# Altium schematic sheet DRIVER.SchDoc(DRIVER)
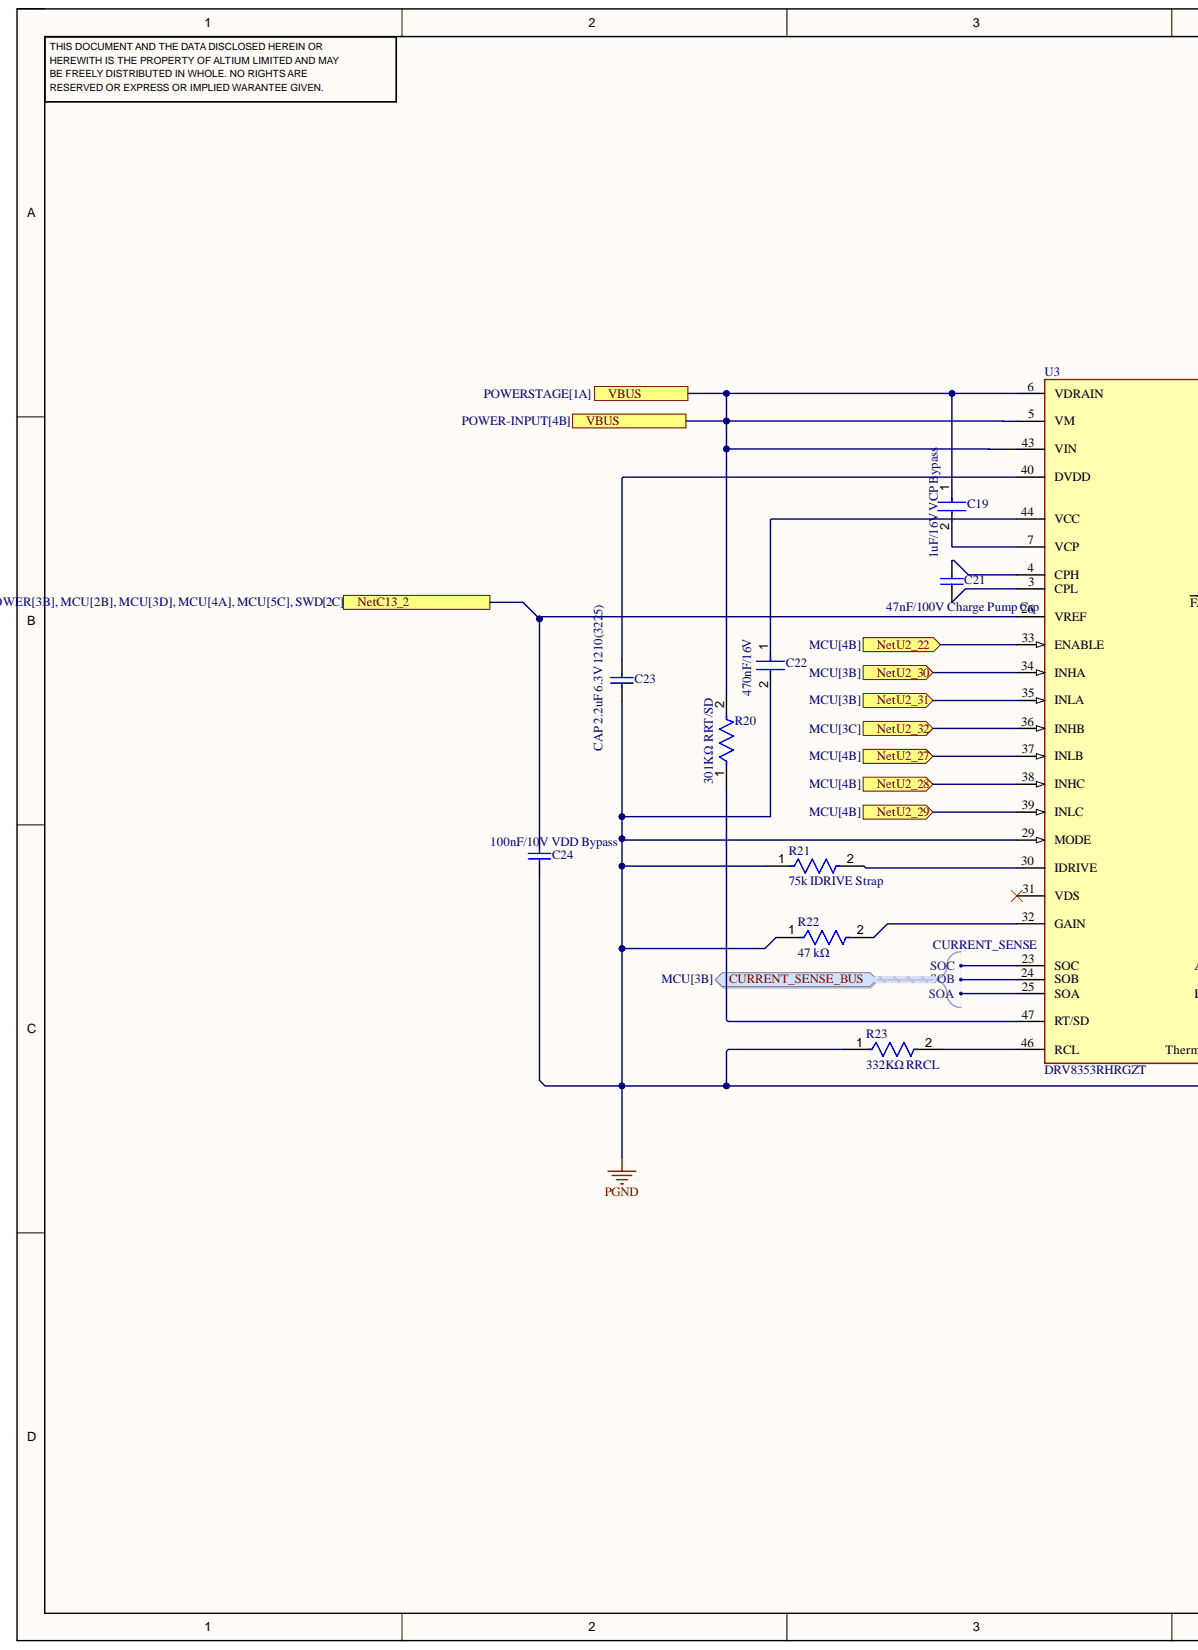
[diagram p0: DRIVER.SchDoc, left]
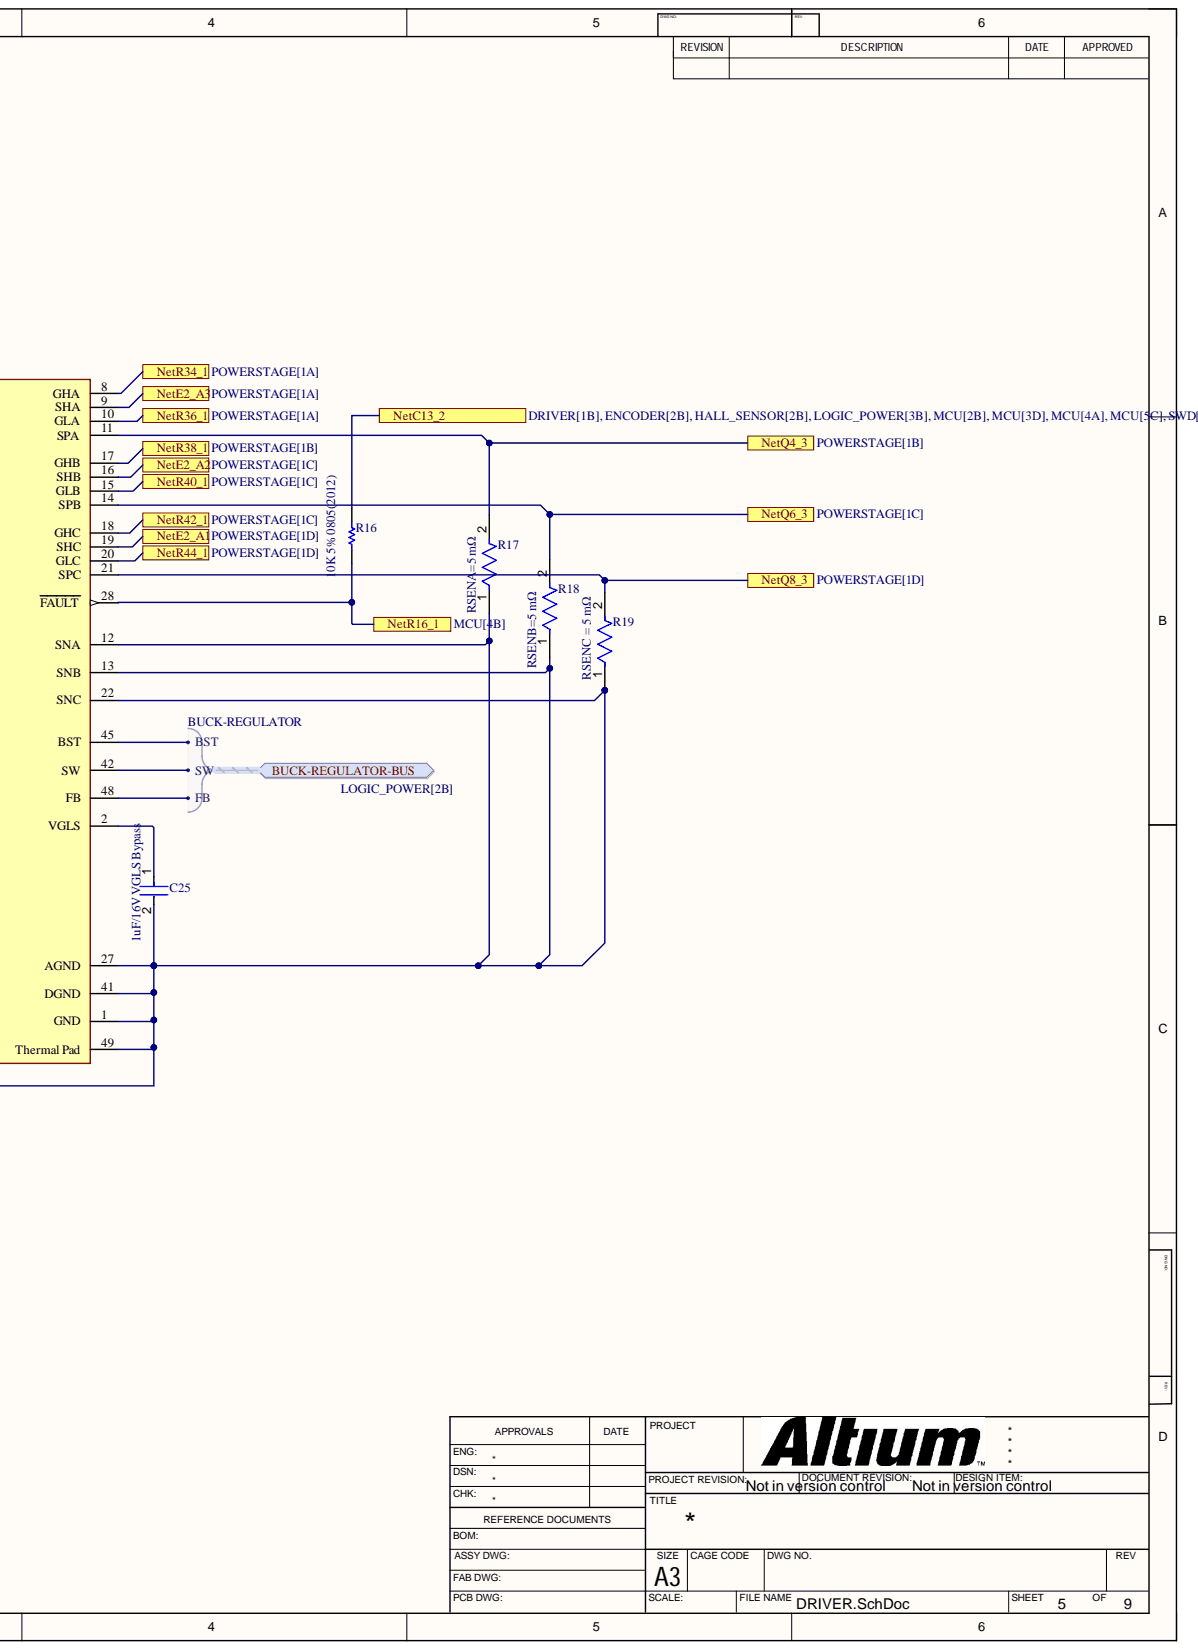
[diagram p1: DRIVER.SchDoc, right]

## Parts
C19  1uF/16V VCP Bypass  [FP-0603-L_1_6_0_1-W_0_8_0-IPC_A]
C21  47nF/100V Charge Pump Cap  [CAPC1206(3216)170_L]
C22  470nF/16V  [FP-0201-L_0_6_0_09-W_0_3-MFG]
C23  CAP 2.2uF 6.3V 1210(3225)  [CAPC2013X145X50ML20T20]
C24  100nF/10V VDD Bypass  [CAPC0805(2012)100_N]
C25  1uF/16V VGLS Bypass  [FP-0603-L_1_6_0_1-W_0_8_0-IPC_A]
R16  10K 5% 0805(2012)  [RESC0805(2012)_L]
R17  RSENA=5 mΩ  [FP-CRE2512-R005-R009-MFG]
R18  RSENB=5 mΩ  [FP-CRE2512-R005-R009-MFG]
R19  RSENC = 5 mΩ  [FP-CRE2512-R005-R009-MFG]
R20  301KΩ  RRT/SD  value 301KΩ  Resistance: 301KΩ  [FP-RP73P-1J-MFG]
R21  75k IDRIVE Strap  [FP-CRCW0603-e3-IPC_C]
R22  47 kΩ  [FP-CRCW0805-HPe3-IPC_B]
R23  332KΩ RRCL  value 332KΩ  Resistance: 332KΩ  [FP-RN732A-MFG]
U3  DRV8353RHRGZT  [FP-RGZ0048L-MFG]

## Ports
3.3V-LOGIC-SUPPLY, BUCK-REGULATOR-BUS, BUCK-REGULATOR-BUS.BST, BUCK-REGULATOR-BUS.FB, BUCK-REGULATOR-BUS.SW, CURRENT_SENSE_BUS, CURRENT_SENSE_BUS.SOA, CURRENT_SENSE_BUS.SOB, CURRENT_SENSE_BUS.SOC, ENABLE, FAULT, GHA, GHB, GHC, GLA, GLB, GLC, INHA, INHB, INHC, INLA, INLB, INLC, SHA, SHB, SHC, SPA, SPB, SPC, VBUS_MOTOR, VDRAIN

## Nets
GND: C22.2 C23.1 C24.1 C25.2 R17.1 R18.1 R19.1 R21.1 R22.1 R23.1 U3.1(GND) U3.12(SNA) U3.13(SNB) U3.22(SNC) U3.27(AGND) U3.29(MODE) U3.41(DGND) U3.49(Thermal_Pad)
3.3V-LOGIC-SUPPLY: C24.2 R16.2 U3.26(VREF) ; port 3.3V-LOGIC-SUPPLY
ENABLE: U3.33(ENABLE) ; port ENABLE
GHA: U3.8(GHA) ; port GHA
GHB: U3.17(GHB) ; port GHB
GHC: U3.18(GHC) ; port GHC
GLA: U3.10(GLA) ; port GLA
GLB: U3.15(GLB) ; port GLB
GLC: U3.20(GLC) ; port GLC
INHA: U3.34(INHA) ; port INHA
INHB: U3.36(INHB) ; port INHB
INHC: U3.38(INHC) ; port INHC
INLA: U3.35(INLA) ; port INLA
INLB: U3.37(INLB) ; port INLB
INLC: U3.39(INLC) ; port INLC
SHA: U3.9(SHA) ; port SHA
SHB: U3.16(SHB) ; port SHB
SHC: U3.19(SHC) ; port SHC
SPA: R17.2 U3.11(SPA) ; port SPA
SPB: R18.2 U3.14(SPB) ; port SPB
SPC: R19.2 U3.21(SPC) ; port SPC
VBUS_MOTOR: C19.1 R20.2 U3.5(VM) U3.6(VDRAIN) U3.43(VIN) ; port VBUS_MOTOR, port VDRAIN
NetC19_2: C19.2 U3.7(VCP)
NetC21_1: C21.1 U3.3(CPL)
NetC21_2: C21.2 U3.4(CPH)
NetC22_1: C22.1 U3.44(VCC)
NetC23_2: C23.2 U3.40(DVDD)
NetC25_1: C25.1 U3.2(VGLS)
NetR16_1: R16.1 U3.28(FAULT) ; port FAULT
NetR20_1: R20.1 U3.47(RT/SD)
NetR21_2: R21.2 U3.30(IDRIVE)
NetR22_2: R22.2 U3.32(GAIN)
NetR23_2: R23.2 U3.46(RCL)
NetU3_23: U3.23(SOC) ; port CURRENT_SENSE_BUS
NetU3_24: U3.24(SOB) ; port CURRENT_SENSE_BUS
NetU3_25: U3.25(SOA) ; port CURRENT_SENSE_BUS
NetU3_42: U3.42(SW) ; port BUCK-REGULATOR-BUS
NetU3_45: U3.45(BST) ; port BUCK-REGULATOR-BUS
NetU3_48: U3.48(FB) ; port BUCK-REGULATOR-BUS

Not connected: U3 31(VDS)

## Library data of the parts
C19 C25 = CMP-14477-003559-2: None; Component Kind: Standard; Library Name: Altium Content Vault
C21 = CMP-1037-03992-1: CAP 47nF 100V ±5% 1206 (3216 Metric) Thickness 1.7mm SMD; Case-EIA: 1206; Case-Metric: 3216; Tolerance: Â±5%; Component Kind: Standard; Library Name: Altium Content Vault; Max Thickness: 1.7 mm; Rated Voltage: 100 V; Technology: SMT; Value: 47nF
C22 = CMP-14477-002862-2: None; Component Kind: Standard; Library Name: Altium Content Vault; RoHS Compliant: Yes
C23 = CMP-2007-01334-1: 0805 2200 nF 6.3 V ±10 % Tolerance X5R SMT Multilayer Ceramic C; Manufacturer: Vitramon; Part Number: VJ0805G225KXYTW1BC; Component Kind: Standard; Library Name: Altium Content Vault
C24 = CMP-1036-04396-1: CAP 100nF 10V ±20% 0805 (2012 Metric) Thickness 1mm SMD; Case-EIA: 0805; Case-Metric: 2012; Tolerance: Â±20%; Component Kind: Standard; Library Name: Altium Content Vault; Max Thickness: 1 mm; Rated Voltage: 10 V; Technology: SMT; Value: 100nF
R16 = CMP-1013-00098-1: 10K 0.125W 5% 0805 (2012 Metric)  SMD; Case-EIA: 0805; Case-Metric: 2012; Value: 10000.00 Ohm; Component Kind: Standard; Library Name: Altium Content Vault; Power: 0.125 W; Technology: SMT; Tolerance: 5 %
R17-R19 = CMP-07231-006697-1: RES 0.005 OHM 1% 3W 2512; Resistance: 5mR; Temperature Coefficient: 75ppm/°C; Case Code (Imperial): 2512; Case Code (Metric): 6432; Case/Package: 2512; Component Kind: Standard; Contact Plating: Tin; Depth: 3.35mm; Features: Moisture Resistant; Height: 0.035inch; Length: 0.254inch; Library Name: Altium Content Vault; Max Operating Temperature: 170°C; Max Power Dissipation: 3W; Min Operating Temperature: -55°C; Mount: Surface Mount; Number of Terminations: 2; Packaging: Cut Tape; Power Rating: 3W; REACH SVHC: No SVHC; Resistor Type: Metal Film; Termination: Solder; Tolerance: 1%; Width: 0.132inch
R20 = CMP-03211-008448-1: RP73P 0603 301KΩ 0.166W 25ppm/°C 0.10% 100V; Manufacturer: TE Connectivity; Manufacturer Part Number: RP73PF1J301KBTDF; Tolerance: 0.001; Automotive: No; Category: Res; Component Kind: Standard; ComponentLink2Description: Datasheet; ComponentLink2URL: https://www.te.com/commerce/DocumentDelivery/DDEController?Action=srchrtrv&DocNm=1773272&DocType=DS&DocLang=English; Composition: Thin Film; Device Class L1: Passive Components; Device Class L2: Resistors; Device Class L3: Chip SMD Resistors; Height: 0.55mm; Ipc Land Pattern Name: RESC15580X45; Lead Free: Yes; Library Name: Altium Content Vault; Octopart: https://octopart.com/rp73pf1j301kbtdf-te+connectivity-45465933; Package: RP73P-1J; Power Rating: 0.166W; RoHS: TRUE; Series: RP73P; Temperature: -55°C to +155°C; Temperature Coefficient: 25ppm/°C; Temperature Range High: +155°C; Temperature Range Low: -55°C; Voltage Rating: 100V
R21 = CMP-02407-006358-1: RES Thick Film, 75Ω, 1%, 0.1W, 100ppm/°C, 0603; Max Power Dissipation: 100mW; Resistance: 75R; Case/Package: 0603; Component Kind: Standard; Composition: Thick Film; Contact Plating: Tin; Depth: 0.85mm; Features: Automotive AEC-Q200; Height: 0.02inch; Lead Free: Lead Free; Length: 0.061inch; Library Name: Altium Content Vault; Max Operating Temperature: 155°C; Military Standard: Not; Min Operating Temperature: -55°C; Mount: Surface Mount; Number of Terminations: 2; Package Quantity: 20000; Packaging: Tape and Reel; Power Rating: 100mW; Radiation Hardening: No; Temperature Coefficient: 100ppm/°C; Tolerance: 1%; Width: 0.033inch
R22 = CMP-02407-006743-1: RES Thick Film, 47kΩ, 5%, 0.5W, 200ppm/°C, 0805; Max Power Dissipation: 333mW; Power Rating: 500mW; Resistance: 47kR; Voltage Rating: 150V; Case Code (Imperial): 0805; Case Code (Metric): 2012; Case/Package: 0805; Component Kind: Standard; Composition: Thick Film; Contact Plating: Tin; Features: Automotive AEC-Q200; Height: 0.024inch; Lead Free: Lead Free; Length: 0.079inch; Library Name: Altium Content Vault; Max Operating Temperature: 155°C; Min Operating Temperature: -55°C; Number of Terminations: 2; Package Quantity: 1; Packaging: Tape and Reel; Temperature Coefficient: 200ppm/°C; Termination: SMD/SMT; Tolerance: 5%; Weight: 0.000194oz; Width: 0.049inch
R23 = CMP-03211-006914-1: SMD Chip Resistor, Thin Film, 332KΩ, 100 V, 0805 [2012 Metric], 100 mW, 0.1%, RN73 Series; Manufacturer: TE Connectivity; Manufacturer Part Number: RN73C2A332KBTG; Power Rating: 0.1W; Temperature Coefficient: 10ppm/°C; Voltage Rating: 100V; Automotive: No; Category: Res; Component Kind: Standard; ComponentLink2Description: Datasheet; ComponentLink2URL: https://www.te.com/commerce/DocumentDelivery/DDEController?Action=showdoc&DocId=Data+Sheet%7F1773270%7FP%7Fpdf%7FEnglish%7FENG_DS_1773270_P.pdf%7F1676162-2; Composition: Thin Film; Device Class L1: Passive Components; Device Class L2: Resistors; Device Class L3: Chip SMD Resistors; Height: 0.65mm; Ipc Land Pattern Name: RESC200125X55; Lead Free: Yes; Library Name: Altium Content Vault; Octopart: https://octopart.com/rn73c2a332kbtg-te+connectivity-44606045; octopart_url: https://octopart.com/rn73c2a332kbtg-te+connectivity-44606045; Package: RN732A; packaging: 250 cut tape length; RoHS: TRUE; Series: RN73; Temperature: -55°C to 155°C; Temperature Range High: 155°C; Temperature Range Low: -55°C; Tolerance: 0.1%
U3 = CMP-04915-000086-1: IC MTR DRV MULTIPHAS 6-95V 48QFN; Output Current: 25mA; Case/Package: VQFN; Component Kind: Standard; Library Name: Altium Content Vault; Max Operating Temperature: 125°C; Min Operating Temperature: -40°C; Mount: Surface Mount; Packaging: Tape and Reel; RoHS Compliant: Yes
